# Altium schematic sheet Schematics_Sheet.SchDoc(Schematics_Sheet)
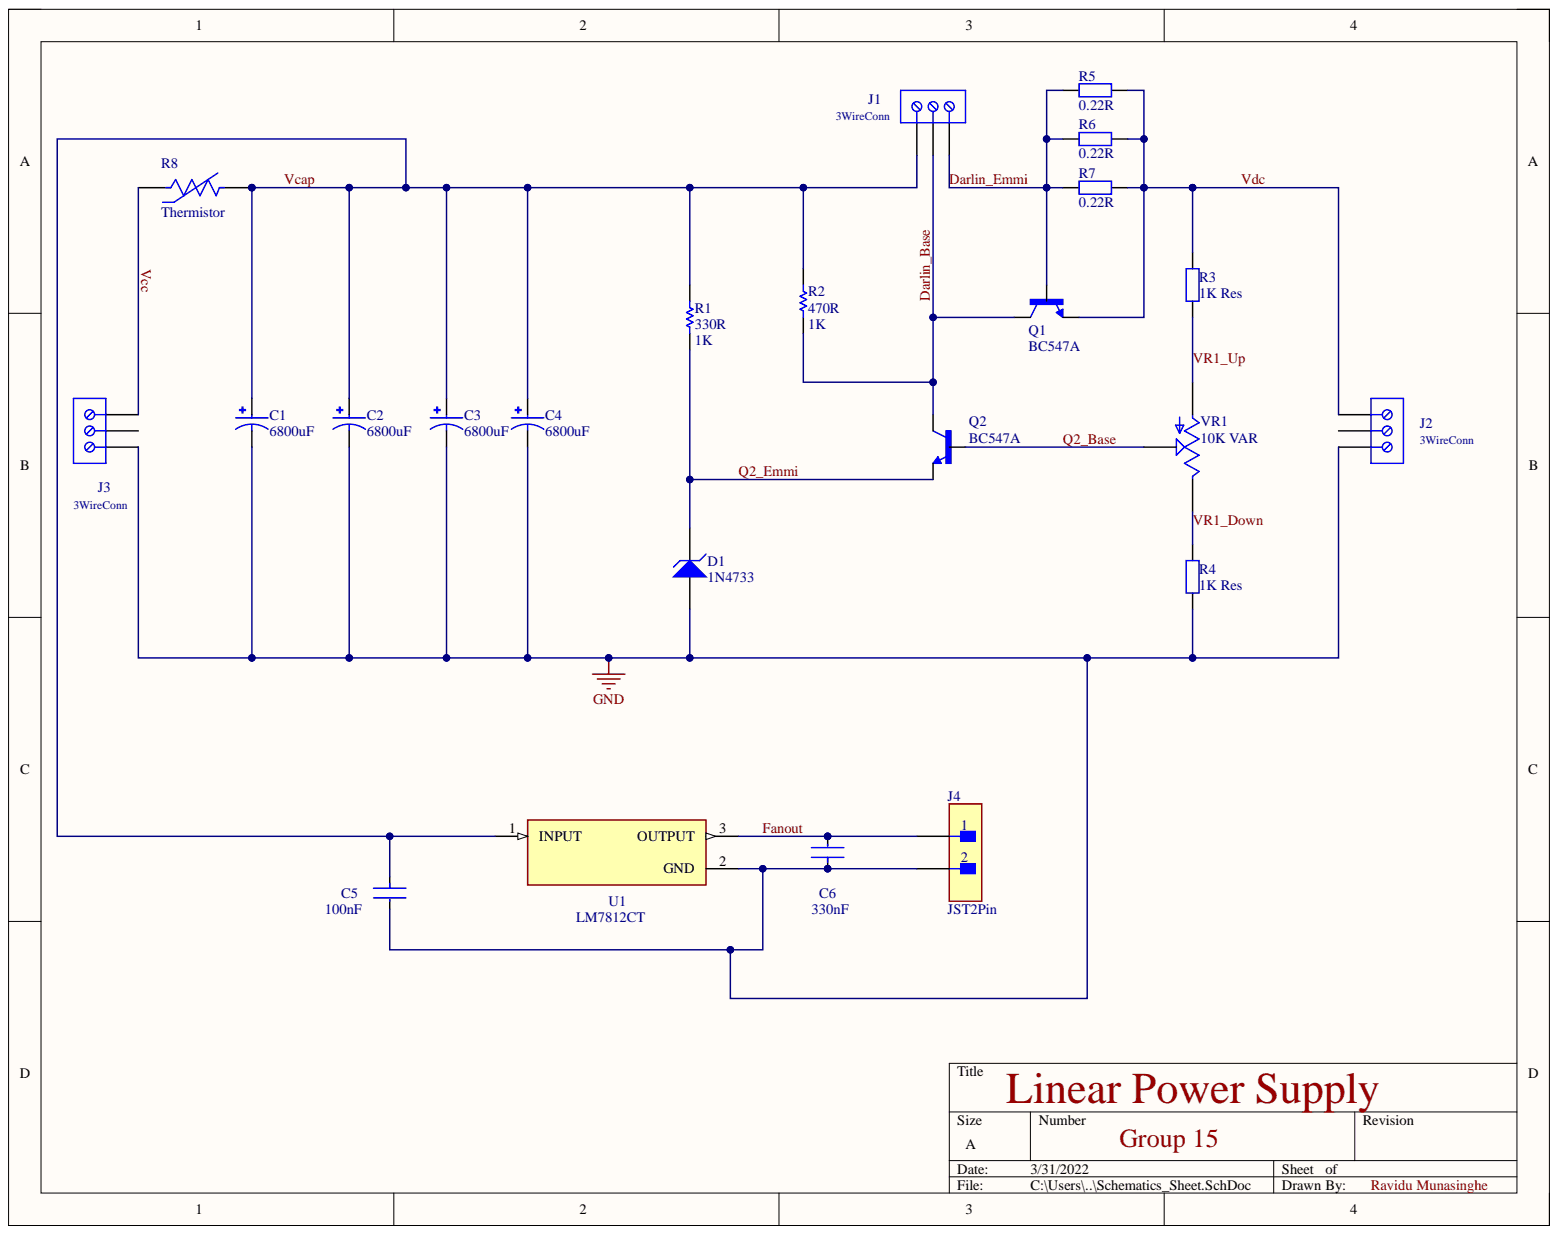
[diagram p0: Schematics_Sheet.SchDoc]

## Parts
C1  6800uF  [Capacitor]
C2  6800uF  [Capacitor]
C3  6800uF  [Capacitor]
C4  6800uF  [Capacitor]
C5  100nF  [capacitor 0.1uf]
C6  330nF  [Cpacitor 0.33uf]
D1  1N4733  [1N4733]
J1  3WireConn  [Connector]
J2  3WireConn  [Connector]
J3  3WireConn  [Connector]
J4  JST2Pin  [JST 2 Pin]
Q1  BC547A  [BC547]
Q2  BC547A  [BC547]
R1  330R  value 1K  [AXIAL-0.5]
R2  470R  value 1K  [AXIAL-0.5]
R3  1K Res  [AXIAL-0.4]
R4  1K Res  [AXIAL-0.4]
R5  0.22R  [0.22 Ohm Ceramic Res]
R6  0.22R  [0.22 Ohm Ceramic Res]
R7  0.22R  [0.22 Ohm Ceramic Res]
R8  Thermistor  [Thermistor]
U1  LM7812CT  [Fan_Regulator]
VR1  10K VAR  [Var_Res_Final]

## Nets
GND: C1.2 C2.2 C3.2 C4.2 C5.2 C6.1 D1.1 J2.3 J3.1 J4.2 R4.1 U1.2
Darlin_Base: J1.2 Q1.1 Q2.1 R2.2
Darlin_Emmi: J1.3 Q1.2 R5.1 R6.1 R7.1
Fanout: C6.2 J4.1 U1.3
Q2_Base: Q2.2 VR1.2
Q2_Emmi: D1.2 Q2.3 R1.2
Vcap: C1.1 C2.1 C3.1 C4.1 C5.1 J1.1 R1.1 R2.1 R8.2 U1.1
Vcc: J3.3 R8.1
Vdc: J2.1 Q1.3 R3.2 R5.2 R6.2 R7.2
VR1_Down: R4.2 VR1.3
VR1_Up: R3.1 VR1.1

Not connected: J2 2; J3 2

## Library data of the parts
C1-C4 = 493-11046-ND: 6800 µF 35 V Aluminum Electrolytic Capacitors Radial, Can - 2000 Hrs @ 85°C; MF: Panasonic; MP: EEUHD1V681B; Availability: In Stock; Check_prices: https://www.snapeda.com/parts/EEUHD1V681B/Panasonic/view-part/5784870/?ref=eda; Component Kind: Standard; Library Name: Schematics.SchLib; Package: RADIAL-2 Panasonic; Price: None; Purchase-URL: https://pricing.snapeda.com/search/part/EEUHD1V681B/?ref=eda; SnapEDA_Link: https://www.snapeda.com/parts/EEUHD1V681B/Panasonic/view-part/5784870/?ref=snap
C5 = CMP-06035-052488-1: Cap Ceramic 100nF 100V X7R ±10% Radial 2.5mm +125°C Automotive Ammo Pack; Lead Diameter: 0.5mm; Lead/Base Style: Straight; Capacitance: 100nF; Case/Package: Radial; Component Kind: Standard; Dielectric: X7R; Height: 3.5mm; Height - Seated (Max): 0.138inch; Lead Free: Lead Free; Lead Pitch: 0.098inch; Length: 0.157inch; Library Name: Schematics.SchLib; Max Operating Temperature: 125°C; Min Operating Temperature: -55°C; Mount: Through Hole; Package Quantity: 2000; Packaging: Tape and Reel; Ratings: AEC-Q200; Resistor Type: Ceramic; Termination: Radial; Tolerance: 10%; Voltage Rating: 100V; Voltage Rating (DC): 100V; Width: 0.098inch
C6 = CMP-06035-045092-1: Cap Ceramic Monolithic 330nF 100V 10% Thru-Hole Bulk; Dielectric: X7R; Lead Diameter: 0.5mm; Lead/Base Style: Formed Leads; Capacitance: 330nF; Case/Package: Radial; Component Kind: Standard; Height: 3.5mm; Height - Seated (Max): 0.138inch; Lead Pitch: 0.197inch; Length: 0.157inch; Library Name: Schematics.SchLib; Max Operating Temperature: 125°C; Min Operating Temperature: -55°C; Mount: Through Hole; Package Quantity: 500; Packaging: Bulk; Ratings: AEC-Q200; Resistor Type: Ceramic; Termination: Radial; Tolerance: 10%; Voltage Rating: 100V; Voltage Rating (DC): 100V; Width: 2.5mm
D1 = D Zener: Zener Diode 5.1V; Component Kind: Standard; LatestRevisionDate: 17-Jul-2002; LatestRevisionNote: Re-released for DXP Platform.; Library Name: Schematics.SchLib; PackageDescription: Diode, Thru-Hole; 2 Leads; 0.7 in Pin Spacing; PackageReference: DIODE-0.7; Published: 8-Jun-2000; Signal Integrity: D Zener; Simulation: ZENER; Publisher: Altium Limited
J1-J3 = CMP-1502-02614-1: Serie 2365 - 5.08 mm Horizontal Cable Entry Modular with Rising Cage Clamp WR-TBL, 3 pin; Manufacturer: Wurth Elektronik; Part Number: 691236510003; Pin Number: 3; Pitch: 5.08mm; Component Kind: Standard; Datasheet URL: http://katalog.we-online.de/em/datasheet/691236510003.pdf; Library Name: Schematics.SchLib; Manufacturer URL: http://www.we-online.de; Mounting Technology: THT; Operating Temperature: -30to120degC; Package Reference: 691236510003; Packaging: Box; Publisher: WurthElektronik
J4 = CMP-17439-000031-1: CONN HEADER VERT 2POS 3.96MM; Connector Type: Male Pin; Number of Positions: 2; Pitch: 0.156inch; Color: Natural; Component Kind: Standard; Contact Plating: Tin; Contact Type: Male Pin; Fastening Type: Ramp; Lead Free: Lead Free; Library Name: Schematics.SchLib; Mount: Through Hole; Number of Rows: 1; Package Quantity: 250; Packaging: Bulk; Series: VH; Termination: Solder
Q1 Q2 = 2368-BC547A-ND: Bipolar (BJT) Transistor NPN 45 V 100 mA 300MHz 625 mW Through Hole TO-92; MF: ON Semiconductor; Availability: Unavailable; Component Kind: Standard; Library Name: Schematics.SchLib; MP: BC547A; Package: TO-92 NXP Semiconductors; Price: None; SnapEDA_Link: https://www.snapeda.com/parts/BC547A/ON%20Semiconductor/view-part/805861/?ref=snap
R1 = 2368-F1W133-ND: 330 Ohms ±5% 1W Through Hole Resistor Axial Fusible, Safety; Component Kind: Standard; LatestRevisionDate: 17-Jul-2002; LatestRevisionNote: Re-released for DXP Platform.; Library Name: Schematics.SchLib; PackageDescription: Axial Device, Thru-Hole; 2 Leads; 0.5 in Pin Spacing; PackageReference: AXIAL-0.5; Published: 8-Jun-2000; Signal Integrity: Res Semi; Simulation: RES; Publisher: Altium Limited
R2 = 470W-1-ND: 470 Ohms ±5% 1W Through Hole Resistor Axial Flame Proof, Safety Metal Oxide Film; Component Kind: Standard; LatestRevisionDate: 17-Jul-2002; LatestRevisionNote: Re-released for DXP Platform.; Library Name: Schematics.SchLib; PackageDescription: Axial Device, Thru-Hole; 2 Leads; 0.5 in Pin Spacing; PackageReference: AXIAL-0.5; Published: 8-Jun-2000; Signal Integrity: Res Semi; Simulation: RES; Publisher: Altium Limited
R3 R4 = CF14JT1K00TR-ND: 1 kOhms ±5% 0.25W, 1/4W Through Hole Resistor Axial Flame Retardant Coating, Safety Carbon Film; Component Kind: Standard; LatestRevisionDate: 17-Jul-2002; LatestRevisionNote: Re-released for DXP Platform.; Library Name: Schematics.SchLib; PackageDescription: Axial Device, Thru-Hole; 2 Leads; 0.4 in Pin Spacing; PackageReference: AXIAL-0.4; Published: 8-Jun-2000; Signal Integrity: Res; Simulation: RESISTOR; Publisher: Altium Limited
R5-R7 = 280-CR10-0.22-RC: Wirewound Resistors - Through Hole 0.22ohms 5% Tol 7W; Component Kind: Standard; LatestRevisionDate: 17-Jul-2002; LatestRevisionNote: Re-released for DXP Platform.; Library Name: Schematics.SchLib; PackageDescription: Axial Device, Thru-Hole; 2 Leads; 0.4 in Pin Spacing; PackageReference: AXIAL-0.4; Published: 8-Jun-2000; Signal Integrity: Res; Simulation: RESISTOR; Publisher: Altium Limited
R8 = CMP-06022-000249-1: Thermistor PTC 470Ω 2-Pin Screw Mount Radial Bulk; Current Rating: 100mA; Lead Length: 39mm; Max Supply Voltage: 16V; Resistance: 100R; Tolerance: 20%; Case/Package: Radial; Component Kind: Standard; Depth: 3mm; Lead Free: Lead Free; Length: 7.5mm; Library Name: Schematics.SchLib; Max Operating Temperature: 130°C; Min Operating Temperature: -10°C; Mount: Through Hole; Number of Pins: 2; Operating Supply Voltage: 16V; Package Quantity: 200; Packaging: Bulk; Radiation Hardening: No; REACH SVHC: No SVHC; Termination: Radial; Voltage: 16V
U1 = CMP-07128-000015-1: IC REG LINEAR 12V 1A TO220-3; Manufacturer: ON Semiconductor; Obsolete Part: true; Accuracy Percentage: 4%; Dropout Voltage: 2V; Max Supply Voltage: 35V; Nominal Supply Current: 5.1mA; Number Of Outputs: 1; Output Type: Fixed; Standoff Height: 2.7mm; Automotive: No; Category: IC; Component Kind: Standard; ComponentLink2Description: Datasheet; ComponentLink2URL: https://www.mouser.in/datasheet/2/308/LM7812-1125088.pdf; Device Class L1: Integrated Circuits (ICs); Device Class L2: Power Management ICs; Device Class L3: Voltage Regulators - Linear; Digikey Description: IC REG LINEAR 12V 1A TO220-3; Height: 20.4mm; Ipc Land Pattern Name: TO-220; Lead Free: Yes; Library Name: Schematics.SchLib; Manufacturer Part Number: LM7812CT; Octopart: https://octopart.com/lm7812ct-on+semiconductor-14383031; Output Current: 1A; Output Voltage: 12V; Package: TO220B03; RoHS: TRUE; Temperature: -40°C to +125°C; Temperature Range High: +125°C; Temperature Range Low: -40°C
VR1 = 478-601030-ND: 10 kOhms 0.1W, 1/10W PC Pins Through Hole Trimmer Potentiometer Carbon 1.0 Turn Top Adjustment; MF: Bourns; MP: 3362P-1-503RLF; Check_prices: https://www.snapeda.com/parts/3362P-1-503RLF/Bourns/view-part/1444210/?ref=eda; Component Kind: Standard; Digi-Key_Part_Number: 118-3362P-1-503RLFCT-ND; Library Name: Schematics.SchLib; Package: 3362P-1 Bourns Inc.; Purchase-URL: https://pricing.snapeda.com/search/part/3362P-1-503RLF/?ref=eda; SnapEDA_Link: https://www.snapeda.com/parts/3362P-1-503RLF/Bourns/view-part/1444210/?ref=snap
